# Altium schematic sheet master_schematic.SchDoc
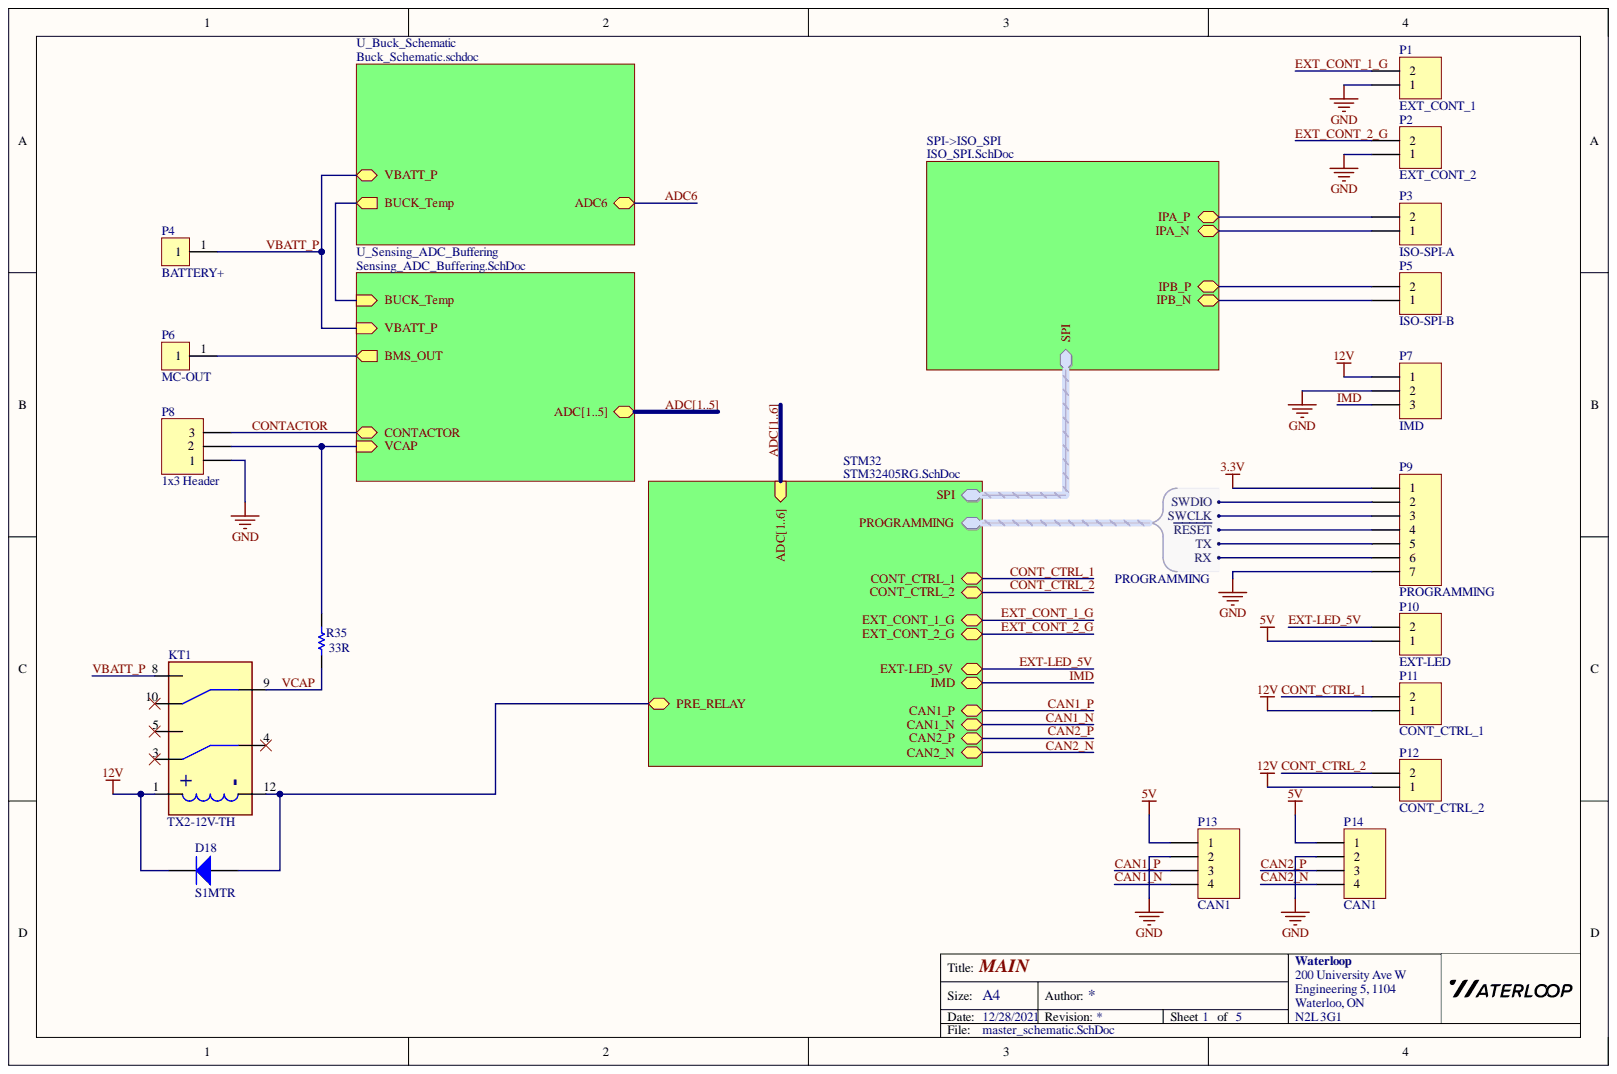
[diagram p0: master_schematic.SchDoc]

## Parts
D18  value S1MTR  Manufacturer Part Number: S1MTR  [SMA - DIODE]  (hidden comment: Diode)
KT1  TX2-12V-TH  [EC2-12NU]
P1  EXT_CONT_1  [B2B-XH]
P2  EXT_CONT_2  [B2B-XH]
P3  ISO-SPI-A  [B2B-XH]
P4  BATTERY+  [74650094R]
P5  ISO-SPI-B  [B2B-XH]
P6  MC-OUT  [74650094R]
P7  IMD  [B3B-XH]
P8  1x3 Header  [B3B-XH]
P9  PROGRAMMING  [HDR1X7]  (no BOM)
P10  EXT-LED  [B2B-XH]
P11  CONT_CTRL_1  [B2B-XH]
P12  CONT_CTRL_2  [B2B-XH]
P13  CAN1  [B4B-XH]
P14  CAN1  [B4B-XH]
R35  value 33R  [2816 - RESISTOR]  (hidden comment: 1K)

## Nets
3.3V: P9.1
5V: P10.1 P13.1 P14.1
12V: D18.2 KT1.1 P7.1 P11.1 P12.1
GND: P1.1 P2.1 P7.2 P8.1 P9.7 P13.2 P14.2
CAN1_N: P13.4
CAN1_P: P13.3
CAN2_N: P14.4
CAN2_P: P14.3
CONT_CTRL_1: P11.2
CONT_CTRL_2: P12.2
CONTACTOR: P8.3
EXT-LED_5V: P10.2
EXT_CONT_1_G: P1.2
EXT_CONT_2_G: P2.2
IMD: P7.3
VBATT_P: KT1.8 P4.1
VCAP: KT1.9 R35.1
NetD18_1: D18.1 KT1.12
NetKT1_3: KT1.3
NetKT1_4: KT1.4
NetKT1_5: KT1.5
NetKT1_10: KT1.10
NetP3_1: P3.1
NetP3_2: P3.2
NetP5_1: P5.1
NetP5_2: P5.2
NetP6_1: P6.1
NetP8_2: P8.2 R35.2
NetP9_2: P9.2
NetP9_3: P9.3
NetP9_4: P9.4
NetP9_5: P9.5
NetP9_6: P9.6
ADC1:  [also labelled ADC]
ADC2:  [also labelled ADC]
ADC3:  [also labelled ADC]
ADC4:  [also labelled ADC]
ADC5:  [also labelled ADC]
ADC6:  [also labelled ADC]
ADC[1..5]:  [also labelled ADC]
BUCK_Temp:
SPI.~{CS}:
SPI.~{CS2}:
SPI.CS:
SPI.MISO:
SPI.MOSI:
SPI.SCK:

## Library data of the parts
D18 = Diode: https://www.digikey.ca/en/products/detail/smc-diode-solutions/S1MTR/6022596; Manufacturer: SMC Diode Solutions; Component Kind: Standard; Library Name: General.SchLib; Signal Integrity: Diode; Simulation: DIODE; Supplier: DigiKey; Supplier Part Number: 1655-1506-1-ND
KT1 = EC2-12NU: TX2-12V-TH, RELAY GEN PURPOSE DPDT 2A 12V; Manufacturer: Panasonic; Component Kind: Standard; Library Name: BMS.SchLib; Manufacturer Part Number: TX2-12V-TH; Supplier: Digikey; Supplier Part Number: TX2-12V-TH-ND
P1-P3 P5 P10-P12 = 1x2 Header: 1x2 Pin Header; Component Kind: Standard; Library Name: GeneralConnectors.SchLib; Signal Integrity: Connector
P4 P6 = 74650094R: Manufacturer: Wurth; Component Kind: Standard; Library Name: GeneralConnectors.SchLib; Manufacturer Part Number: 74650094R; Supplier: Digikey; Supplier Part Number: 732-10884-1-ND
P7 P8 = 1x3 Header: 1x3 Pin Header; Component Kind: Standard; Library Name: GeneralConnectors.SchLib; Signal Integrity: Connector
P9 = 1x7 Header: 1x7 Pin Header; Library Name: GeneralConnectors.SchLib; Signal Integrity: Connector
P13 P14 = 1x4 Header: 1x4 Pin Header; Component Kind: Standard; Library Name: GeneralConnectors.SchLib; Signal Integrity: Connector
R35 = Resistor: Manufacturer: Stackpole; Manufacturer Part Number: RMCF2512JT33R0; Rating: 1W; Component Kind: Standard; Description: Resistor; Library Name: General.SchLib; Signal Integrity: Res; Simulation: RESISTOR; Supplier: Digi-Key; Supplier Part Number: RMCF2512JT33R0CT-ND
